# Altium schematic sheet legdrive.SchDoc(legdrive)
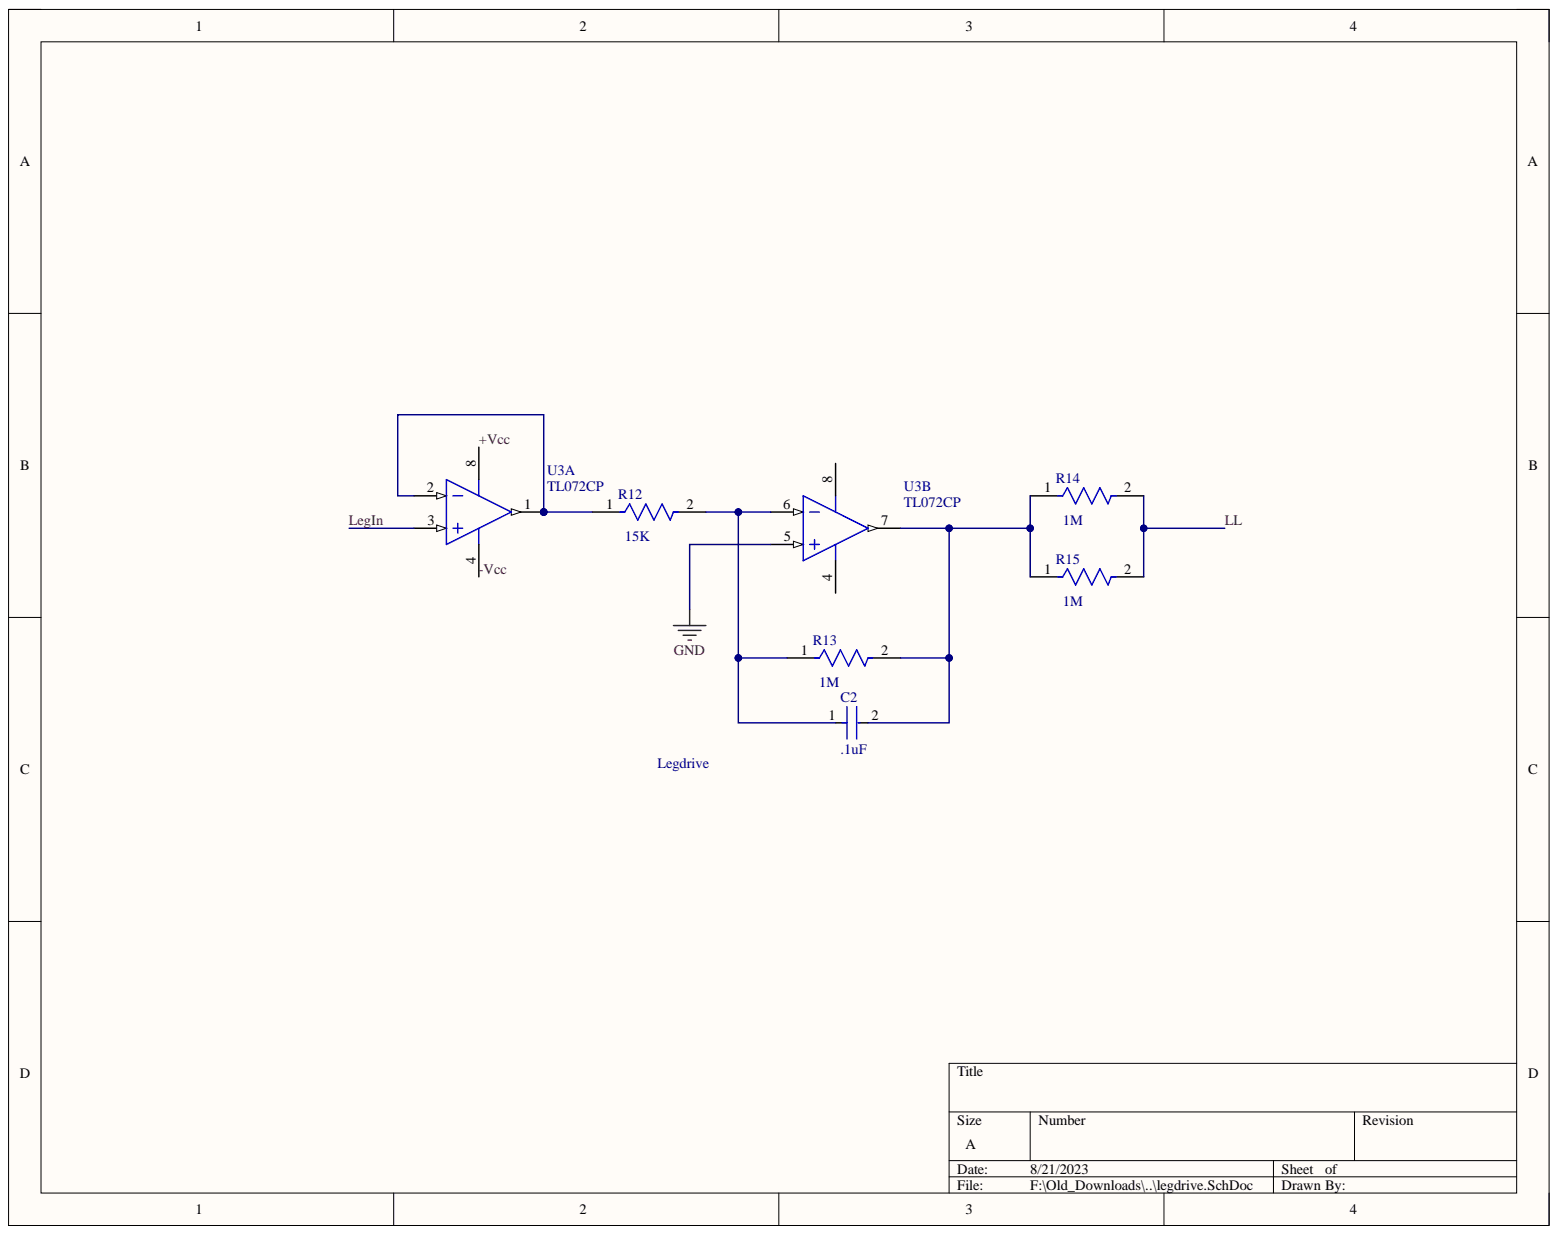
[diagram p0: legdrive.SchDoc]

## Parts
C2  .1uF  [FP-K15H5-MFG]
R12  15K  [FP-CFR-25-MFG]
R13  1M  [FP-CFR-25-MFG]
R14  1M  [FP-CFR-25-MFG]
R15  1M  [FP-CFR-25-MFG]
U3  TL072CP  [P0008A]  (parts A,B)

## Nets
GND: U3.5
+Vcc: U3.8
-Vcc: U3.4
LegIn: U3.3
LL: R14.2 R15.2
NetC2_1: C2.1 R12.2 R13.1 U3.6
NetC2_2: C2.2 R13.2 R14.1 R15.1 U3.7
NetR12_1: R12.1 U3.1 U3.2

## Library data of the parts
C2 = CMP-02417-000048-1: CAP CER 0.1UF 50V X7R RADIAL; Capacitance: 100nF; Lead Diameter: 0.5mm; Lead Length: 30mm; Lead/Base Style: Formed Leads; Tolerance: 10%; Case/Package: Dipped; Component Kind: Standard; Construction: RADIAL; Depth: 2.5mm; Diameter: 500um; Dielectric: X7R; Height: 4mm; Height - Seated (Max): 0.1inch; Lead Pitch: 5mm; Length: 4mm; Library Name: Altium Content Vault; Max Operating Temperature: 125°C; Min Operating Temperature: -55°C; Mount: Through Hole; Package Quantity: 5000; Packaging: Bulk; Radiation Hardening: No; RoHS Compliant: Yes; Series: K; Termination: Radial; Thickness: 2.6mm; Voltage: 50V; Voltage Rating: 50V; Voltage Rating (DC): 50V; Weight: 0.005644oz; Width: 2.5mm
R12-R15 = CMP-03413-000009-1: RES 10 OHM 1/4W 5% AXIAL; Max Power Dissipation: 250mW; Resistance: 10R; Temperature Coefficient: 500ppm/°C; Case/Package: Axial; Component Kind: Standard; Length: 0.248inch; Library Name: Altium Content Vault; Max Operating Temperature: 155°C; Min Operating Temperature: -55°C; Number of Terminations: 2; Package Quantity: 200; Packaging: Bulk; Resistor Type: Carbon Film; RoHS Compliant: Yes; Series: Carbon Film; Tolerance: 5%
U3 = CMP-0017-00950-2: Dual Low-Noise JFET-Input General-Purpose Operational Amplifier, 7 to 36 V, 0 to 70 degC, 8-pin DIP (P8), Pb-Free (RoHS); Manufacturer: Texas Instruments; CMRR(Min)(dB): 70; GBW(Typ)(MHz): 3; IIB(Max)(pA): 200; Iq per channel(Max)(mA): 2.5; Number of Channels: 2; Offset Drift(Typ)(uV/C): 18; Rating: Catalog; Slew Rate(Typ)(V/us): 13; Sub Family: General Purpose Amplifier, High Supply Voltage Amplifier (>=30V); Total Supply Voltage(Max)(+5V is 5, +/-5V is 10): 36; Total Supply Voltage(Min)(+5V is 5, +/-5V is 10): 7; Vio (25C)(Max)(mV): 10; Code_JEDEC: MS-001; Component Kind: Standard; ComponentLink1Description: Manufacturer URL; ComponentLink1URL: http://www.ti.com/; ComponentLink2Description: Datasheet; ComponentLink2URL: http://www.ti.com/lit/ds/symlink/tl072.pdf; ComponentLink3Description: Package Specification; ComponentLink3URL: http://www.ti.com/litv/pdf/mpdi001b; DatasheetVersion: SLOS080J; Eco-Plan: Pb-Free (RoHS); Library Name: Altium Content Vault; Mounting Technology: Through Hole; PackageDescription: 8-Pin Plastic In-Line, Body 9.59 x 6.35 mm, Pitch 2.54 mm; PackageReference: P0008A; PackageVersion: 4040082/E, 04/2010; PartNumber: TL072CP; Vn at 1kHz(Typ)(nV/rtHz): 18
